# Altium schematic sheet MCU_USB.SchDoc("MCU and USB-C")
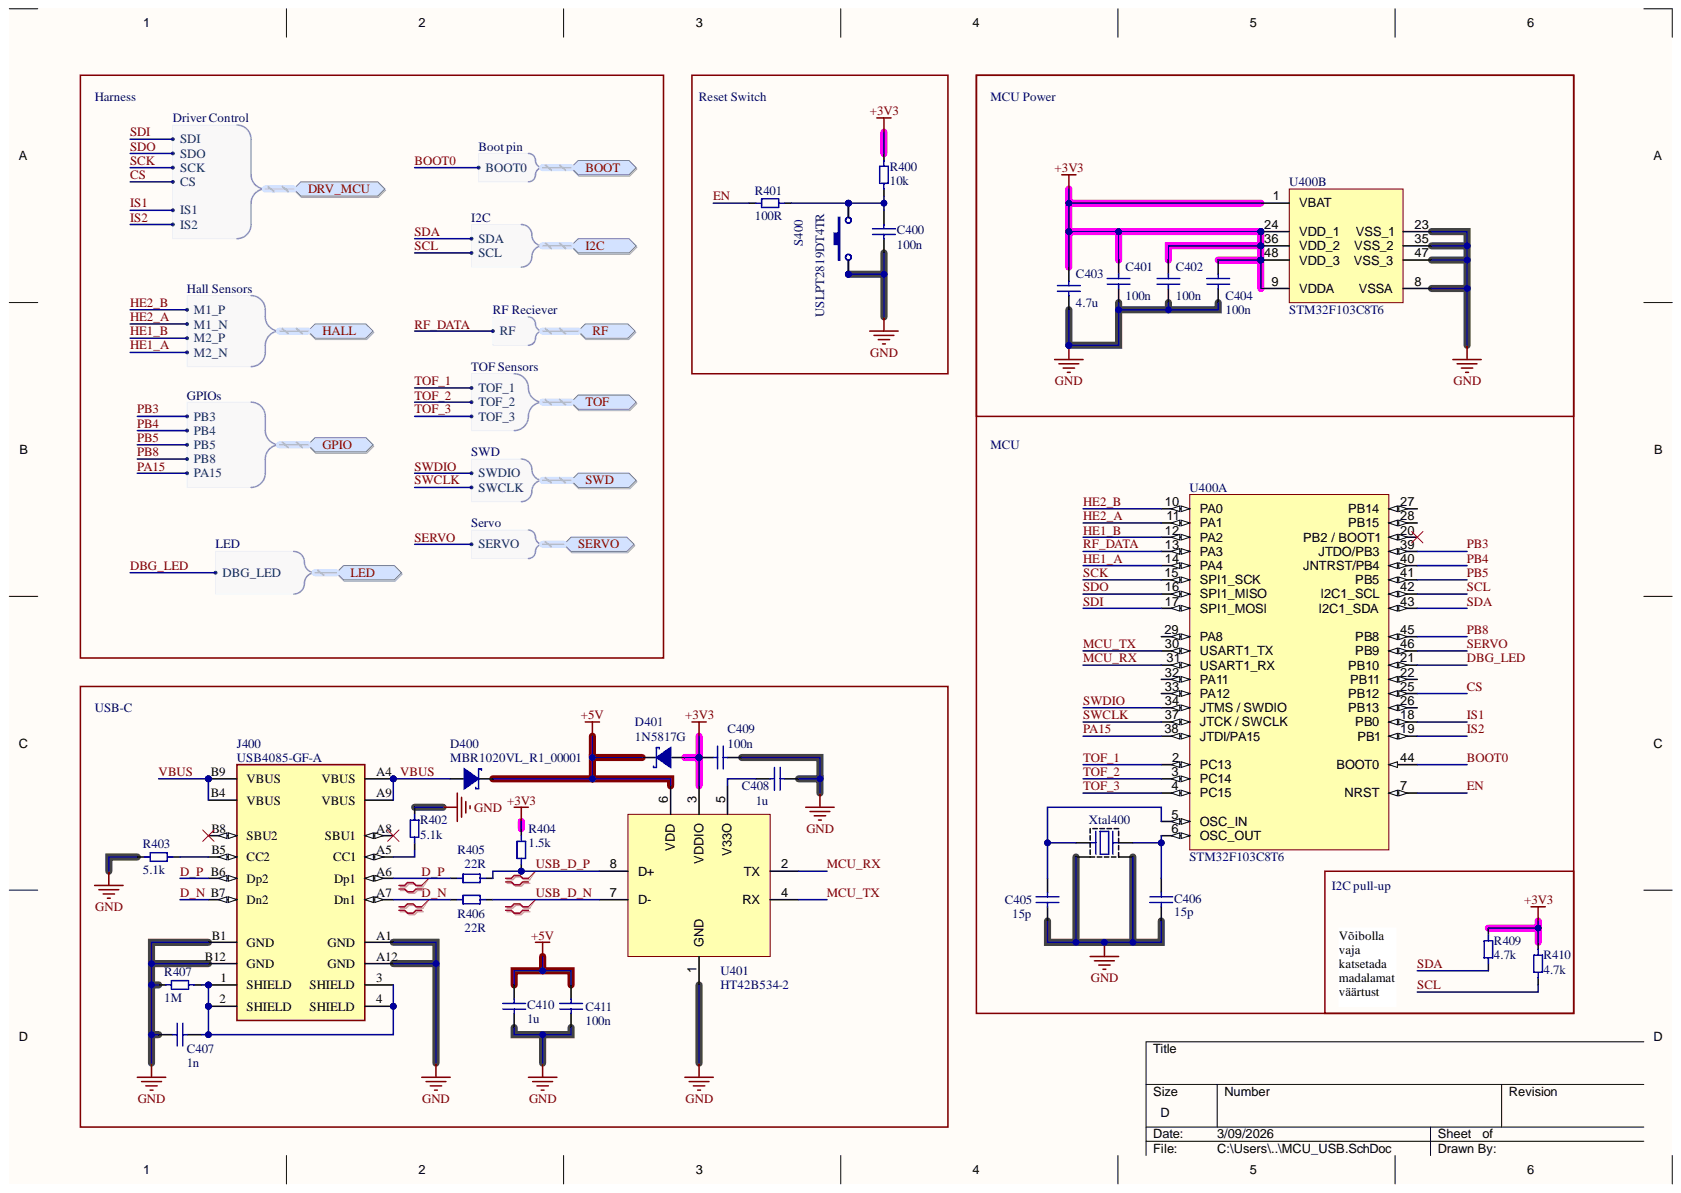
[diagram p0: MCU_USB.SchDoc]

## Parts
C400  100n  [MLCC_0603_M]
C401  100n  [MLCC_0603_M]
C402  100n  [MLCC_0603_M]
C403  4.7u  [MLCC_0805_M]
C404  100n  [MLCC_0603_M]
C405  15p  [MLCC_0402_M]
C406  15p  [MLCC_0402_M]
C407  1n  [MLCC_0603_M]
C408  1u  [MLCC_0603_M]
C409  100n  [MLCC_0603_M]
C410  1u  [MLCC_0603_M]
C411  100n  [MLCC_0603_M]
D400  MBR1020VL_R1_00001  [FP-MBR1020VL_R1_00001-MFG]
D401  1N5817G  [SOD-323 based on 1N5817WS]
J400  USB4085-GF-A  [FP-USB4085-GF-A-MFG]
R400  10k  [Resistor_0603_M]
R401  100R  [Resistor_0603_M]
R402  5.1k  [Resistor_0603_M]
R403  5.1k  [Resistor_0603_M]
R404  1.5k  [Resistor_0603_M]
R405  22R  [Resistor_0603_M]
R406  22R  [Resistor_0603_M]
R407  1M  [Resistor_1206_M]
R409  4.7k  [Resistor_0603_M]
R410  4.7k  [Resistor_0603_M]
S400  USLPT2819DT4TR  [USLPT2819DT4TR-Footprint-1]
U400  STM32F103C8T6  [STM-LQFP48_M]  (parts A,B)
U401  HT42B534-2  [SOP-8 150mil]
Xtal400  ABM11-16.000MHZ-12-N1G-T  [FP-ABM11-MFG]  (value not drawn)

## Ports
BOOT, BOOT.BOOT0, DRV_MCU, DRV_MCU.CS, DRV_MCU.IS1, DRV_MCU.IS2, DRV_MCU.SCK, DRV_MCU.SDI, DRV_MCU.SDO, GPIO, GPIO.PA15, GPIO.PB3, GPIO.PB4, GPIO.PB5, GPIO.PB8, HALL, HALL.M1_N, HALL.M1_P, HALL.M2_N, HALL.M2_P, I2C, I2C.SCL, I2C.SDA, LED, LED.DBG_LED, RF, RF.RF, SERVO, SERVO.SERVO, SWD, SWD.SWCLK, SWD.SWDIO, TOF, TOF.TOF_1, TOF.TOF_2, TOF.TOF_3

## Nets
+3V3: C401.1 C402.1 C403.2 C404.1 C409.1 D401.2 R400.2 R404.2 R409.2 R410.2 U400.1(VBAT) U400.9(VDDA) U400.24(VDD_1) U400.36(VDD_2) U400.48(VDD_3) U401.3(VDDIO)
+5V: C410.2 C411.1 D400.1 D401.1 U401.6(VDD)
GND: C400.1 C401.2 C402.2 C403.1 C404.2 C405.1 C406.1 C407.1 C408.2 C409.2 C410.1 C411.2 J400.A1(GND) J400.A12(GND) J400.B1(GND) J400.B12(GND) R402.2 R403.1 R407.2 S400.1 S400.2 U400.8(VSSA) U400.23(VSS_1) U400.35(VSS_2) U400.47(VSS_3) U401.1(GND) Xtal400.2 Xtal400.4
BOOT0: U400.44(BOOT0) ; port BOOT
CS: U400.25(PB12) ; port DRV_MCU
D_N: J400.A7(Dn1) J400.B7(Dn2) R406.1
D_P: J400.A6(Dp1) J400.B6(Dp2) R405.1
DBG_LED: U400.21(PB10) ; port LED
EN: R401.2 U400.7(NRST)
HE1_A: U400.14(PA4) ; port HALL
HE1_B: U400.12(PA2) ; port HALL
HE2_A: U400.11(PA1) ; port HALL
HE2_B: U400.10(PA0) ; port HALL
IS1: U400.18(PB0) ; port DRV_MCU
IS2: U400.19(PB1) ; port DRV_MCU
MCU_RX: U400.31(USART1_RX) U401.2(TX)
MCU_TX: U400.30(USART1_TX) U401.4(RX)
PA15: U400.38(JTDI/PA15) ; port GPIO
PB3: U400.39(JTDO/PB3) ; port GPIO
PB4: U400.40(JNTRST/PB4) ; port GPIO
PB5: U400.41(PB5) ; port GPIO
PB8: U400.45(PB8) ; port GPIO
RF_DATA: U400.13(PA3) ; port RF
SCK: U400.15(SPI1_SCK) ; port DRV_MCU
SCL: R410.1 U400.42(I2C1_SCL) ; port I2C
SDA: R409.1 U400.43(I2C1_SDA) ; port I2C
SDI: U400.17(SPI1_MOSI) ; port DRV_MCU
SDO: U400.16(SPI1_MISO) ; port DRV_MCU
SERVO: U400.46(PB9) ; port SERVO
SWCLK: U400.37(JTCK_/_SWCLK) ; port SWD
SWDIO: U400.34(JTMS_/_SWDIO) ; port SWD
TOF_1: U400.2(PC13) ; port TOF
TOF_2: U400.3(PC14) ; port TOF
TOF_3: U400.4(PC15) ; port TOF
USB_D_N: R406.2 U401.7(D-)
USB_D_P: R404.1 R405.2 U401.8(D+)
VBUS: D400.2 J400.A4(VBUS) J400.A9(VBUS) J400.B4(VBUS) J400.B9(VBUS)
NetC400_2: C400.2 R400.1 R401.1 S400.3 S400.4
NetC405_2: C405.2 U400.5(OSC_IN) Xtal400.1
NetC406_2: C406.2 U400.6(OSC_OUT) Xtal400.3
NetC407_2: C407.2 J400.1(SHIELD) J400.2(SHIELD) J400.3(SHIELD) J400.4(SHIELD) R407.1
NetC408_1: C408.1 U401.5(V33O)
NetJ400_A5: J400.A5(CC1) R402.1
NetJ400_A8: J400.A8(SBU1)
NetJ400_B5: J400.B5(CC2) R403.2
NetJ400_B8: J400.B8(SBU2)
NetU400_20: U400.20(PB2_/_BOOT1)
NetU400_22: U400.22(PB11)
NetU400_26: U400.26(PB13)
NetU400_27: U400.27(PB14)
NetU400_28: U400.28(PB15)
NetU400_29: U400.29(PA8)
NetU400_32: U400.32(PA11)
NetU400_33: U400.33(PA12)

## Library data of the parts
C400-C402 C404 C409 C411 = CMP-001-0815-1: MLCC, 0603, 100n, 10%, 50V, X7R; Manufacturer: Any; Capacitor Type: Ceramic; Value: 100nF; Case/Package: 0603; Component Kind: Standard; Dielectric: X7R; Mount: SMD; Part number: MLCC, 0603, 100n, 10%, 50V, X7R; RoHS: Yes; Tolerance: 10%; Voltage: 50V; Library Name: Krakul Vault
C403 = CMP-001-1830-1: MLCC, 0805, 4.7u, 20%, 50V, X5R; Manufacturer: Any; Capacitor Type: Ceramic; Value: 4.7µF; Case/Package: 0805; Component Kind: Standard; Dielectric: X5R; Mount: SMD; Part number: MLCC, 0805, 4.7u, 20%, 50V, X5R; RoHS: Yes; Tolerance: 20%; Voltage: 50V; Library Name: Krakul Vault
C405 C406 = CMP-001-1853-1: MLCC, 0402, 15p, 10%, 25V, C0G/NP0; Manufacturer: Any; Capacitance: 15pF; Capacitor Type: Ceramic; Dielectric: C0G; Temperature Coefficient: 300ppm/°C; Value: 15pF; Voltage Rating: 25; Case Code (Imperial): 0402; Case Code (Metric): 1005; Case/Package: 0402; Component Kind: Standard; Contact Plating: Tin; Depth: 0.5mm; Height: 0.5mm; Length: 1mm; Material: Ceramic; Max Operating Temperature: 125°C; Min Operating Temperature: -55°C; Mount: Surface Mount; Package Quantity: 1; Packaging: Tape and Reel; Part number: MLCC, 0402, 15p, 10%, 25V, C0G/NP0; Radiation Hardening: No; RoHS: Yes; Thickness: 0.022inch; Tolerance: 10%; Voltage: 25V; Width: 0.02inch; Library Name: Krakul Vault
C407 = CMP-001-0547-1: MLCC, 0603, 1n, 10%, 50V, X5R; Manufacturer: Any; Capacitor Type: Ceramic; Value: 1nF; Case/Package: 0603; Component Kind: Standard; Dielectric: X5R; Mount: SMD; Part number: MLCC, 0603, 1n, 10%, 50V, X5R; RoHS: Yes; Tolerance: 10%; Voltage: 50V; Library Name: Krakul Vault
C408 C410 = CMP-001-0565-1: MLCC, 0603, 1u, 10%, 50V, X5R; Manufacturer: Any; Capacitor Type: Ceramic; Value: 1µF; Case/Package: 0603; Component Kind: Standard; Dielectric: X5R; Mount: SMD; Part number: MLCC, 0603, 1u, 10%, 50V, X5R; RoHS: Yes; Tolerance: 10%; Voltage: 50V; Library Name: Krakul Vault
D400 = CMP-ROOT-01310-1: Rectifier Diode, Schottky, 1 Phase, 1 Element, 1A, 20V V(RRM), Silicon; Manufacturer: Panjit; Max Forward Surge Current (Ifsm): 45A; Max Junction Temperature (Tj): 125°C; Number of Elements: 1; Peak Reverse Current: 600µA; Case/Package: SOD-123FL; Component Kind: Standard; Forward Current: 1A; Height: 1.1mm; Lifecycle Status: Production; Max Operating Temperature: 125°C; Max Output current: 1A; Max Repetitive Reverse Voltage (Vrrm): 20V; Min Operating Temperature: -55°C; Mount: SMD; Number of Terminals: 2; Part number: MBR1020VL_R1_00001; Pins: 2; REACH SVHC: No; Reverse Standoff Voltage: 20V; RoHS: Compliant; Library Name: Krakul Vault
D401 = CMP-ROOT-01323-1: Rectifier Diode, Schottky, 1 Phase, 1 Element, 1A, 20V V(RRM), Silicon, DO-204AL; Manufacturer: TWGMC / onsemi; Manufacturer Lifecycle Status: ACTIVE; Part number: 1N5817WS; Element Configuration: Single; Forward Voltage: 320mV; Max Forward Surge Current (Ifsm): 25A; Number of Elements: 1; Peak Reverse Current: 1mA; Polarity: Standard; Average Rectified Current: 1A; Breakdown Voltage: 20V; Case/Package: Axial; China RoHS: Non-Compliant; Component Kind: Standard; Country of Origin: Mainland China; Current Rating: 1A; Diameter: 2.7mm; Export Control Classification Number (ECCN) Code: EAR99; Forward Current: 1A; Halogen Free: Halogen Free; Harmonized Tariff Schedule (HTS) Code: 8541.10.00.80; Height: 2.7mm; Introduction Date: 1997-05-06; Lead Free: Lead Free; Length: 5.1816mm; Lifecycle Status: Production; Max Operating Temperature: 125°C; Max Output current: 1A; Max Repetitive Reverse Voltage (Vrrm): 20V; Max Reverse Voltage (DC): 20V; Min Operating Temperature: -65°C; Mount: SMD; Number of Terminals: 2; Output Current: 1A; Packaging: Bulk; Peak Non-Repetitive Surge Current: 25A; Pins: 2; Radiation Hardening: No; REACH SVHC: No; Reverse Standoff Voltage: 20V; RoHS: Compliant; Schedule B: 8541100080, 8541100080; Voltage Rating (DC): 20V; Weight: 4.535924g; Width: 2.7mm; Library Name: Krakul Vault
J400 = CMP-001-1800-1: USB Connector, 16 Contact(s), Female, Right Angle, Solder Terminal, Locking, Receptacle; Manufacturer: GCT; Part number: USB4800-03-A; Current Rating: 4.25A; Mating Cycles: 10000; Number of Contacts: 16; Number of Ports: 1; Shielding: Shielded; Standoff Height: 3.5mm; USB PD: 3.2; USB Standard: USB 2.0; Voltage Rating: 20V; Component Kind: Standard; Connector Type: USB; Contact Material: Copper Alloy; Contact Plating: Gold; Flammability Rating: UL94 V-0; Gender: Receptacle; Height: 3.66mm; Insulation Color: Black; Max Operating Temperature: 85°C; Min Operating Temperature: -40°C; Mount: TH; Mounting Technology: Through Hole,Horizontal; Number of Positions: 16; Orientation: Right Angle; Packaging: Tape and Reel; Pins: 16; RoHS: Yes; Series: USB4085; Termination: Solder; Library Name: Krakul Vault
R400 = CMP-001-0101-2: Resistor, 0603, 10k, 1%, 1/10W; Manufacturer: Any; Power: 100mW; Case/Package: 0603; Component Kind: Standard; Mount: SMD; Part number: Resistor, 0603, 10k, 1%, 1/10W; RoHS: Yes; Tolerance: 1%; Value: 10k; Library Name: Krakul Vault
R401 = CMP-001-0054-5: Resistor, 0603, 100R, 1%, 1/10W; Manufacturer: Any; Power: 100mW; Value: 100; Case/Package: 0603; Component Kind: Standard; Mount: SMD; Part number: Resistor, 0603, 100R, 1%, 1/10W; RoHS: Yes; Tolerance: 1%; Library Name: Krakul Vault
R402 R403 = CMP-001-0094-2: Resistor, 0603, 5.1k, 1%, 1/10W; Manufacturer: Any; Power: 100mW; Value: 5,1k; Case/Package: 0603; Component Kind: Standard; Mount: SMD; Part number: Resistor, 0603, 5.1k, 1%, 1/10W; RoHS: Yes; Tolerance: 1%; Library Name: Krakul Vault
R404 = CMP-001-0081-2: Resistor, 0603, 1.5k, 1%, 1/10W; Manufacturer: Any; Power: 100mW; Value: 1,5k; Case/Package: 0603; Component Kind: Standard; Mount: SMD; Part number: Resistor, 0603, 1.5k, 1%, 1/10W; RoHS: Yes; Tolerance: 1%; Library Name: Krakul Vault
R405 R406 = CMP-001-0038-3: Resistor, 0603, 22R, 1%, 1/10W; Manufacturer: Any; Power: 100mW; Value: 22; Case/Package: 0603; Component Kind: Standard; Mount: SMD; Part number: Resistor, 0603, 22R, 1%, 1/10W; RoHS: Yes; Tolerance: 1%; Library Name: Krakul Vault
R407 = CMP-0001-00051-1: Resistor, 1206, 1M, 1%, 1/4W; Manufacturer: Any; Case/Package: 1206; Component Kind: Standard; Mount: SMD; Part number: Any; RoHS: Yes; Value: 1M; Library Name: Krakul Vault
R409 R410 = CMP-001-0093-2: Resistor, 0603, 4.7k, 1%, 1/10W; Manufacturer: Any; Power: 100mW; Value: 4,7k; Case/Package: 0603; Component Kind: Standard; Mount: SMD; Part number: Resistor, 0603, 4.7k, 1%, 1/10W; RoHS: Yes; Tolerance: 1%; Library Name: Krakul Vault
S400 = CMP-ROOT-01290-1: Keypad Switch, SPST, 0.05A, 1.96N, Solder Terminal, Panel Mount; Manufacturer: TE Connectivity ALCOSWITCH Switches; Contact Current Rating: 50mA; Case/Package: Non-standard; China RoHS: Compliant; Color: Black; Component Kind: Standard; Contact Material: Stainless Steel; Contact Plating: Silver; Housing Material: Polyethylene; Lifecycle Status: Production; Max Current Rating: 50mA; Max Operating Temperature: 85°C; Min Operating Temperature: -40°C; Mount: SMD; Operating Force: 1.96N; Packaging: Tape & Reel; Part number: USLPT2819DT4TR; REACH SVHC: Yes; RoHS: Compliant; Throw Configuration: SPST; Library Name: Krakul Vault
U400 = CMP-00012-3: MCU 32-bit STM32F1 ARM Cortex M3 RISC 64KB Flash 2.5V/3.3V 48-Pin LQFP Tray; Manufacturer: STMicroelectronics; Manufacturer Package Identifier: ai14911d; ADC Channels (Resolution): 10-Ch 12-Bit; Address Bus Width: 32b; Clock Frequency: 16MHz; Density: 512kb; EEPROM Memory Size: 0B; Frequency: 72MHz; Interface: CAN, USB; Max Power Dissipation: 363mW; Max Supply Current: 50mA; Max Supply Voltage: 3.6V; Min Supply Voltage: 2V; Nominal Supply Current: 1.7µA; Number of A/D Converters: 2; Number of ADC Channels: 10; Number of Bits: 32; Number of I/Os: 37; Number of Interrupts: 16; Number of Programmable I/O: 48; Number of PWM Channels: 1; Number of Timers/Counters: 4; Number of USART Channels: 3; Operating Supply Voltage: 3.3V; Oscillator Type: Internal; Peripherals: POR; RAM Size: 20kB; Watchdog Timer: Yes; Case/Package: LQFP; China RoHS: Compliant; Component Kind: Standard; Core Architecture: ARM; Data Bus Width: 32b; Flash Memory Size: 64kB; Height: 1.45mm; Height - Seated (Max): 1.6mm; Lead Free: Lead Free; Length: 7mm; Lifecycle Status: Production; Max Operating Temperature: 85°C; Memory Format: FLASH; Memory Size: 64kB; Min Operating Temperature: -40°C; Mount: SMD; Mounting Technology: Surface Mount; Number of Channels: 10; Number of I2C Channels: 2; Number of SPI Channels: 2; Number of Terminals: 48; Part number: STM32F103C8T6; Pins: 48; Radiation Hardening: No; REACH SVHC: Yes; RoHS: Compliant; Schedule B: 8542310000; Terminal Pitch: 500µm; Termination: SMD/SMT; Width: 7mm; Library Name: Krakul Vault
U401 = CMP-00027-2: USB to UART 3Mbps USB 2.0 SOP-8 Interface Controllers RoHS; Manufacturer: HOLTEK; Case/Package: SOP-8; Component Kind: Standard; Harmonized Tariff Schedule (HTS) Code: 8542.31.00.30; Introduction Date: 2019-03-26; Lifecycle Status: NRND; Mount: SMD; Part number: HT42B534-2; Pins: 8; RoHS: Yes; Library Name: Krakul Vault
Xtal400 = CMP-ROOT-01254-3: Crystal 16MHz ±10ppm (Tol) ±15ppm (Stability) 12pF FUND 150Ohm 4-Pin Ultra Mini-CSMD T/R; Manufacturer: Abracon LLC; ESR: 150Ω; Frequency Tolerance: 0.001%; Case/Package: 4-SMD, No Lead; China RoHS: Compliant; Component Kind: Standard; ESR (Equivalent Series Resistance): 150Ω; Frequency: 16MHz; Frequency Stability: 15ppm; Height: 590µm; Length: 2mm; Lifecycle Status: Production; Load Capacitance: 12pF; Max Operating Temperature: 85°C; Min Operating Temperature: -30°C; Mount: SMD; Mounting Technology: Surface Mount; REACH SVHC: Yes; RoHS: Compliant; Schedule B: 8541600080; Tolerance: 0.001%; Width: 1.6mm; Library Name: Krakul Vault
